# Altium schematic sheet 3V3Reg.SchDoc
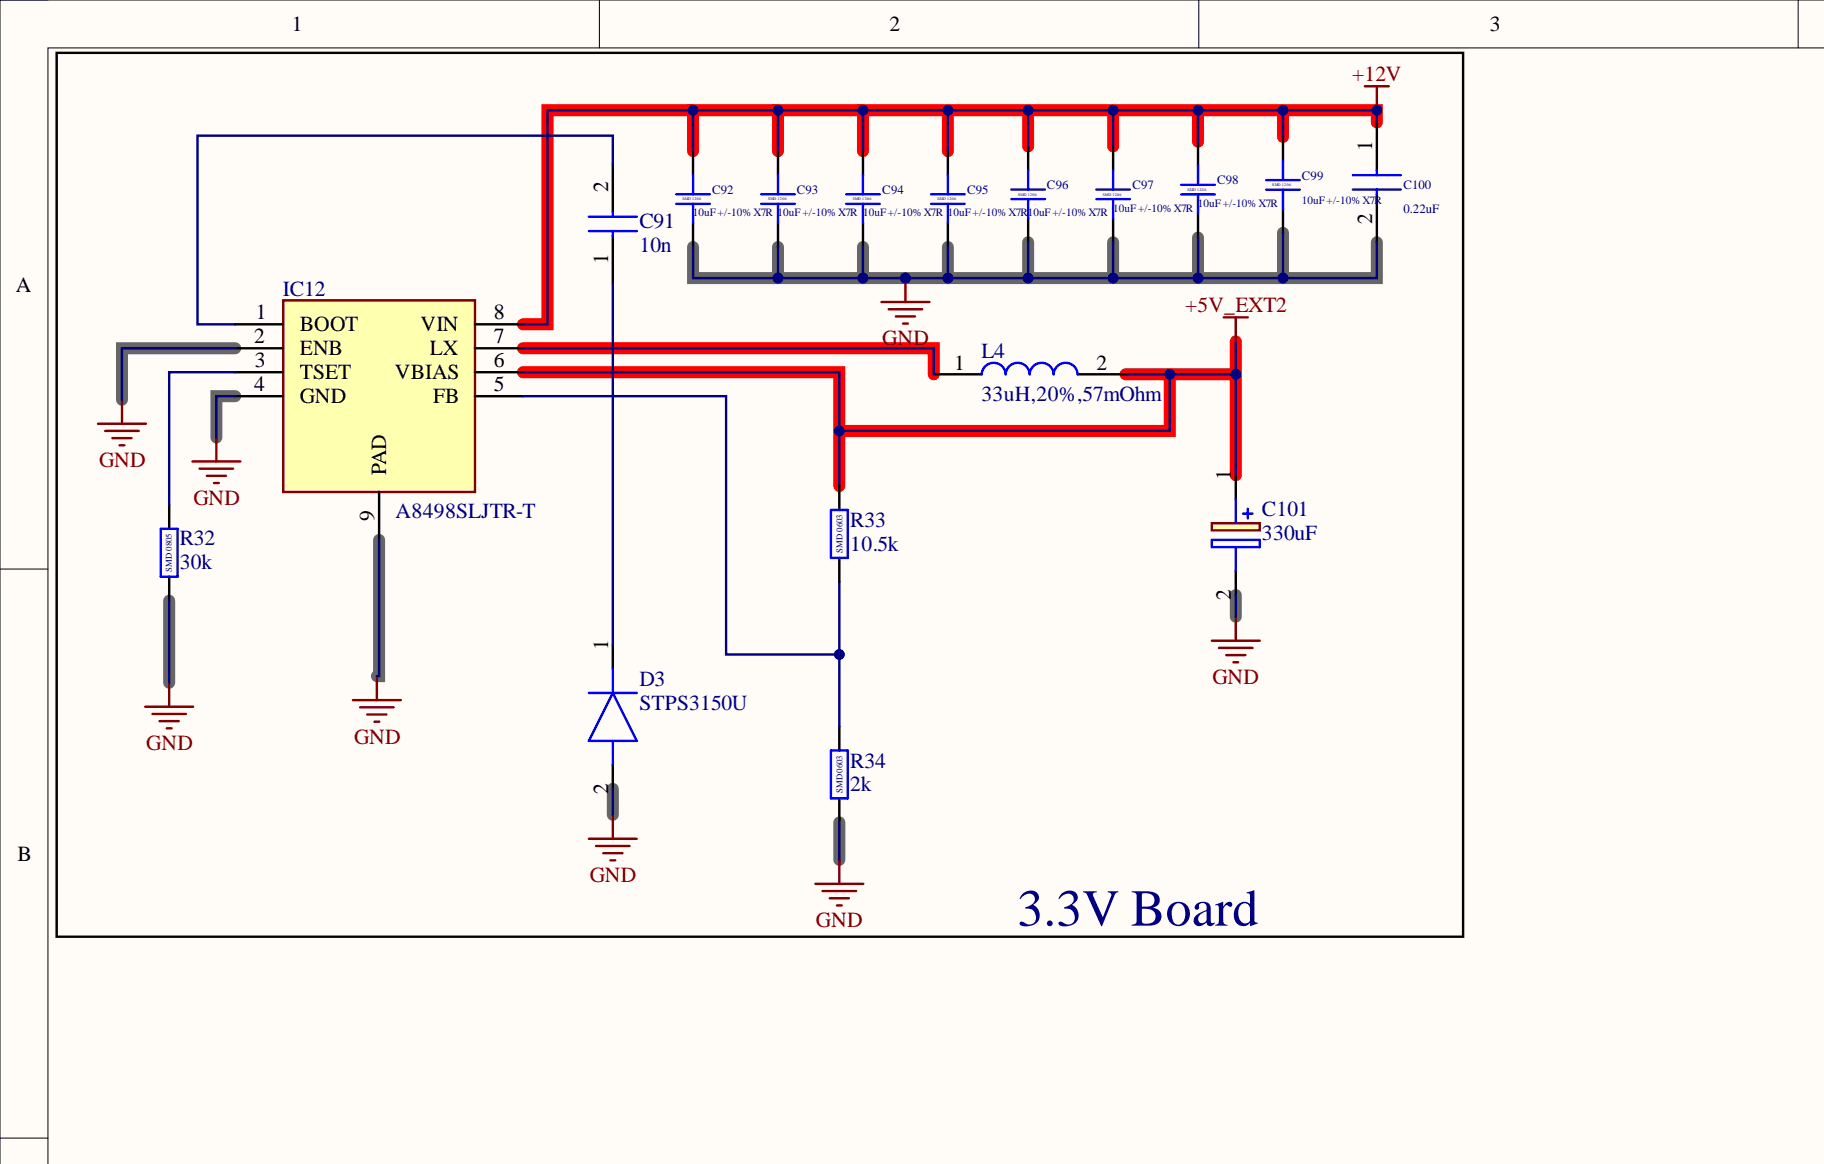
[diagram p0: 3V3Reg.SchDoc, top left]
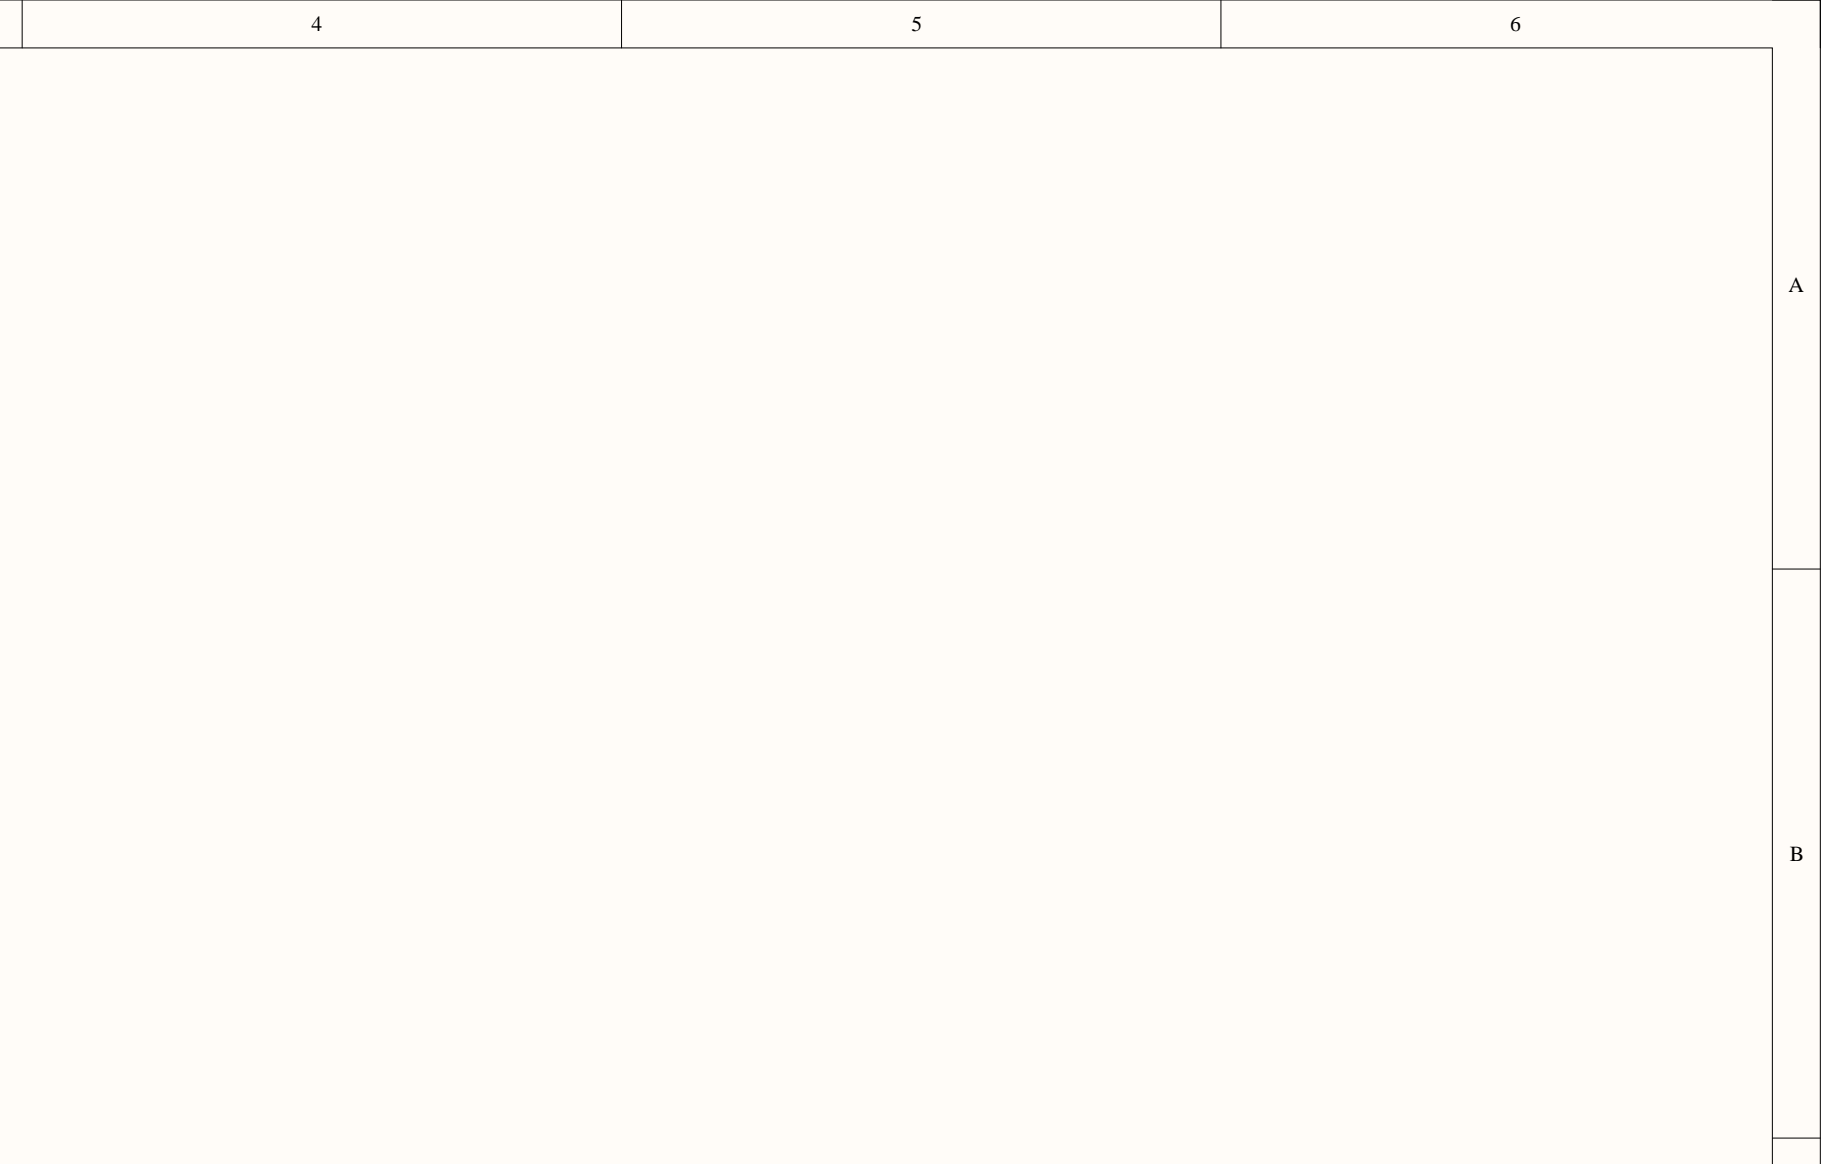
[diagram p1: 3V3Reg.SchDoc, top right]
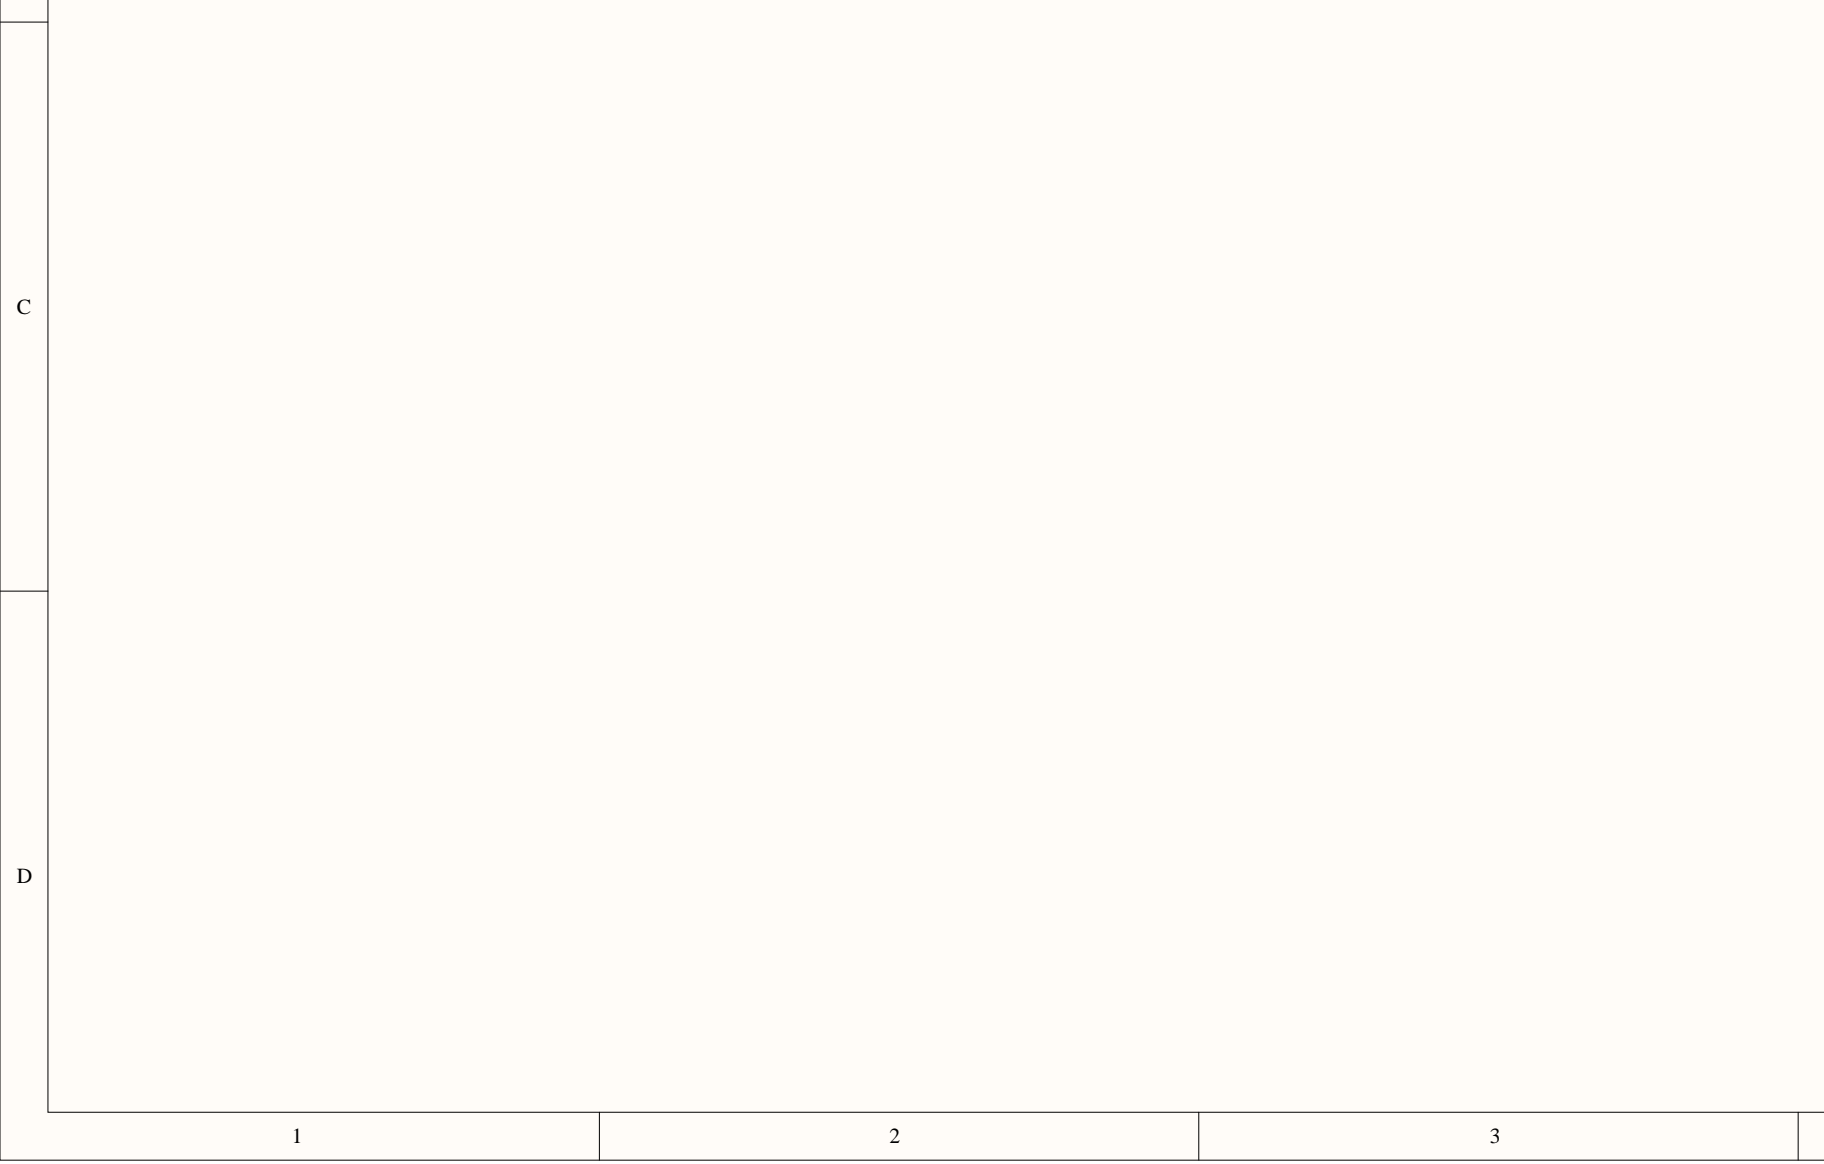
[diagram p2: 3V3Reg.SchDoc, bottom left]
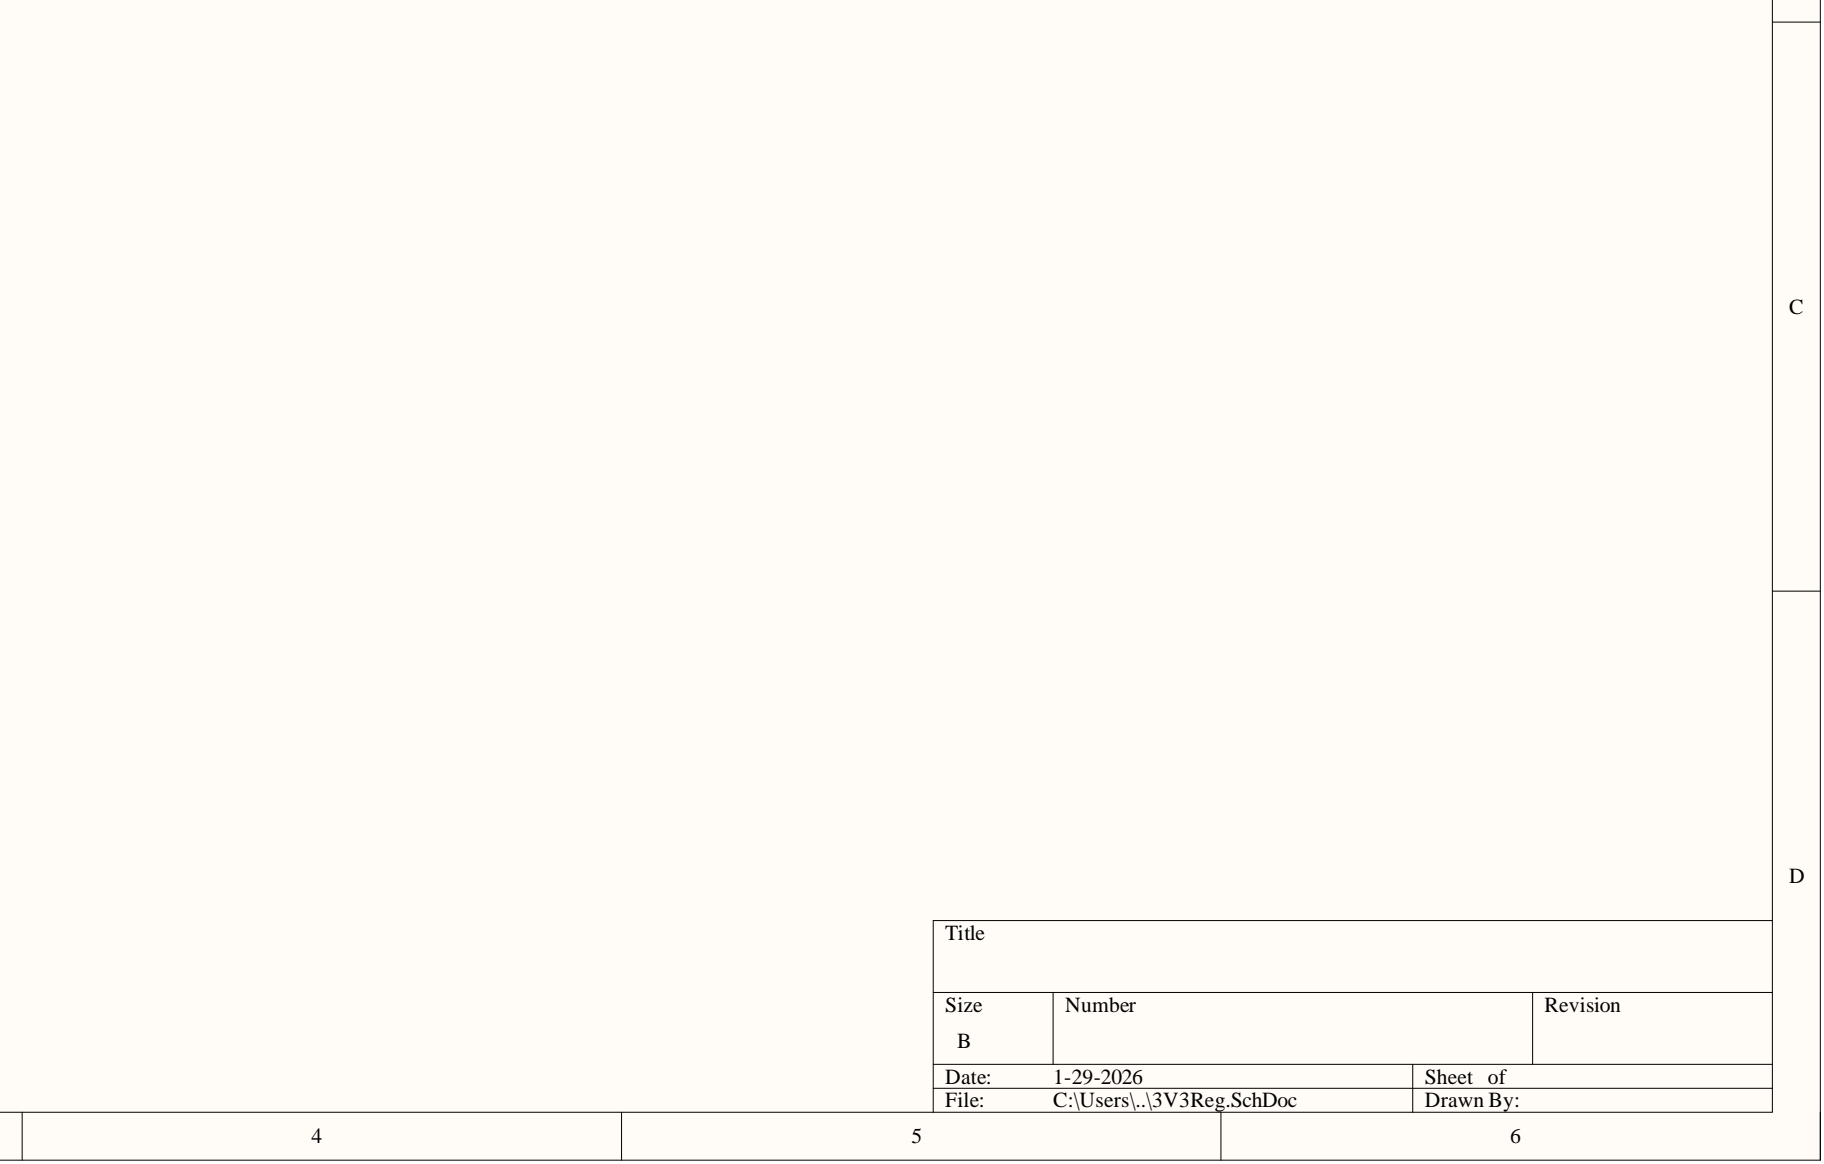
[diagram p3: 3V3Reg.SchDoc, bottom right]

## Parts
C91  10n  [CAP_0603]
C92  10uF +/-10% X7R  [CAP_1206]
C93  10uF +/-10% X7R  [CAP_1206]
C94  10uF +/-10% X7R  [CAP_1206]
C95  10uF +/-10% X7R  [CAP_1206]
C96  10uF +/-10% X7R  [CAP_1206]
C97  10uF +/-10% X7R  [CAP_1206]
C98  10uF +/-10% X7R  [CAP_1206]
C99  10uF +/-10% X7R  [CAP_1206]
C100  0.22uF  [CAP_0805]
C101  330uF  [T495D]
D3  STPS3150U  [SMBJ50CA]
IC12  A8498SLJTR-T  [SOIC127P600X175-8N]
L4  33uH,20%,57mOhm  [INDPM134126X515N]
R32  30k  [RES_0805]
R33  10.5k  [RES_0603]
R34  2k  [RES_0603]

## Nets
+5V_EXT2: C101.1 IC12.6(VBIAS) L4.2 R33.2
+12V: C92.1 C93.1 C94.1 C95.1 C96.1 C97.1 C98.1 C99.1 C100.1 IC12.8(VIN)
GND: C92.2 C93.2 C94.2 C95.2 C96.2 C97.2 C98.2 C99.2 C100.2 C101.2 D3.2 IC12.2(ENB) IC12.4(GND) IC12.9(PAD) R32.1 R34.1
NetC91_1: C91.1 D3.1
NetC91_2: C91.2 IC12.1(BOOT)
NetIC12_3: IC12.3(TSET) R32.2
NetIC12_5: IC12.5(FB) R33.1 R34.2
NetIC12_7: IC12.7(LX) L4.1

## Library data of the parts
C91 = C1608X7R1H103K080AA: Capacitor; Manufacturer_Name: TDK; Arrow Part Number: C1608X7R1H103K080AA; Arrow Price/Stock: https://www.arrow.com/en/products/c1608x7r1h103k080aa/tdk?utm_currency=USD&region=europe; Component Kind: Standard; Datasheet Link: https://product.tdk.com/info/en/catalog/datasheets/mlcc_commercial_midvoltage_en.pdf; Height: 0.8mm; Manufacturer_Part_Number: C1608X7R1H103K080AA; Mouser Part Number: 810-C1608X7R1H103K; Mouser Price/Stock: https://www.mouser.co.uk/ProductDetail/TDK/C1608X7R1H103K080AA?qs=NRhsANhppD90z4jf8QJnTg%3D%3D
C92-C99 = Capacitor-SMD-1206: Capacitor SMD 1206 RATED; Component Kind: Standard; Library Name: STeRG-Passives-Standard.SchLib
C100 = 08055C104JAT2A: Capacitor; Manufacturer_Name: TDK; Component Kind: Standard; Datasheet Link: https://datasheets.kyocera-avx.com/X7RDielectric.pdf; Height: 0.94mm; Manufacturer_Part_Number: 08055C104JAT2A
C101 = T495D337K006ATE040: Capacitor Polarised; Manufacturer_Name: KEMET; Component Kind: Standard; Datasheet Link: https://content.kemet.com/datasheets/KEM_T2009_T495.pdf; Height: 3.1mm; Library Name: SamacSys.SchLib; Manufacturer_Part_Number: T495D337K006ATE040
D3 = STPS3150U: Diode; Manufacturer_Name: STMicroelectronics; Arrow Part Number: STPS3150U; Arrow Price/Stock: https://www.arrow.com/en/products/stps3150u/stmicroelectronics?utm_currency=USD&region=europe; Component Kind: Standard; Datasheet Link: https://www.st.com/resource/en/datasheet/stps3150.pdf; Height: 2.65mm; Library Name: SamacSys.SchLib; Manufacturer_Part_Number: STPS3150U; Mouser Part Number: 511-STPS3150U; Mouser Price/Stock: https://www.mouser.co.uk/ProductDetail/STMicroelectronics/STPS3150U?qs=sepekKm5O7mdIVKQ3I2tDA%3D%3D
IC12 = A8498SLJTR-T: Integrated Circuit; Manufacturer_Name: Allegro Microsystems; Arrow Part Number: A8498SLJTR-T; Arrow Price/Stock: https://www.arrow.com/en/products/a8498sljtr-t/allegro-microsystems?region=nac; Component Kind: Standard; Datasheet Link: http://www.allegromicro.com/~/media/files/datasheets/a8498-datasheet.ashx; Height: 1.7mm; Manufacturer_Part_Number: A8498SLJTR-T; Mouser Part Number: 250-A8498SLJTR-T; Mouser Price/Stock: https://www.mouser.co.uk/ProductDetail/Allegro-MicroSystems/A8498SLJTR-T?qs=pUKx8fyJudCw1xpOohcA8A%3D%3D
L4 = 125CDMCCDS-330MC: Inductor; Manufacturer_Name: Sumida; Arrow Part Number: 125CDMCCDS-330MC; Arrow Price/Stock: https://www.arrow.com/en/products/125cdmccds-330mc/sumida?region=nac; Component Kind: Standard; Datasheet Link: https://products.sumida.com/products/pdf/125CDMCCDS%20(en).pdf; Height: 5.15mm; Library Name: SamacSys.SchLib; Manufacturer_Part_Number: 125CDMCCDS-330MC; Mouser Part Number: 851-125CDMCCDS330MC; Mouser Price/Stock: https://www.mouser.co.uk/ProductDetail/Sumida/125CDMCCDS-330MC?qs=%252BEew9%252B0nqrCW2oHfLcKqBw%3D%3D
R32 = Resistor-SMD-0805: Resistor SMD 0805 RATED; Component Kind: Standard; Library Name: STeRG-Passives-Standard.SchLib
R33 = Resistor-SMD-0603: RK73H1JTTD1052F; Component Kind: Standard; Library Name: STeRG-Passives-Standard.SchLib
R34 = Resistor-SMD-0603: CRCW06032K00FKEA; Component Kind: Standard; Library Name: STeRG-Passives-Standard.SchLib
